# Altium schematic sheet bmsMaster_can.SchDoc
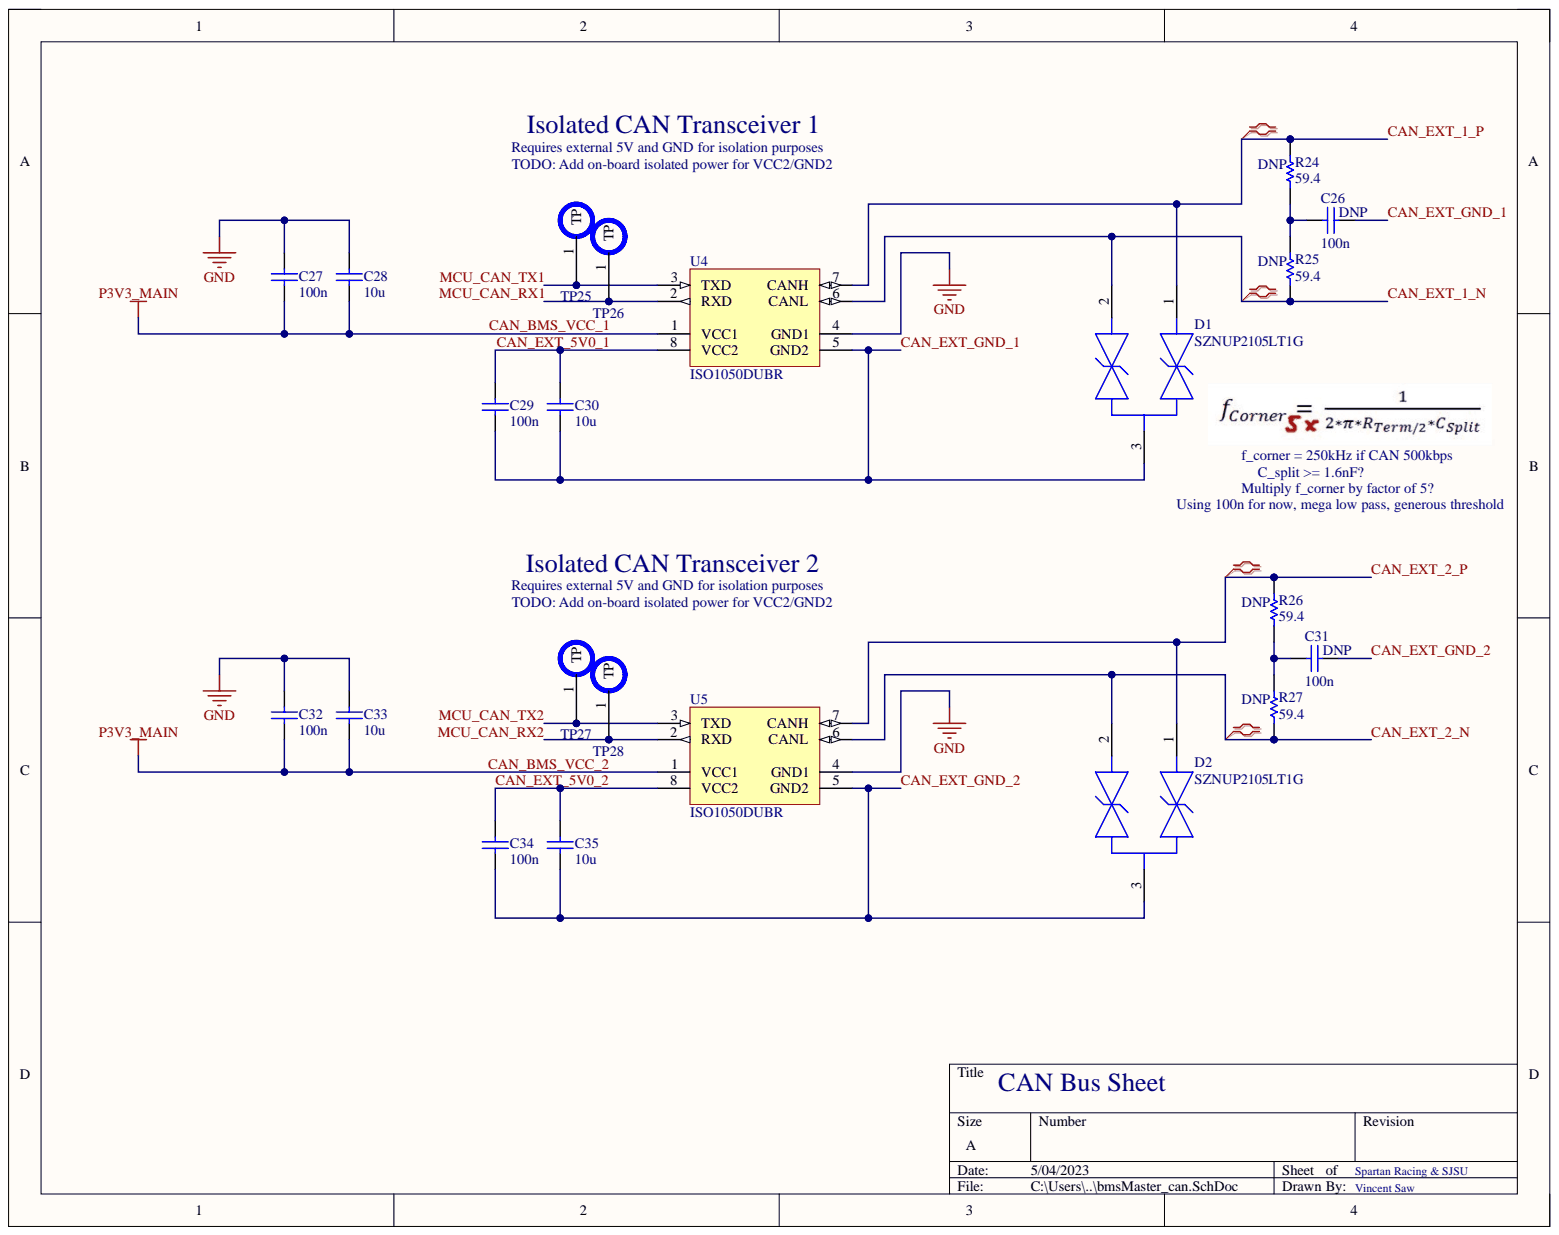
[diagram p0: bmsMaster_can.SchDoc]

## Parts
C26  value 100n  (hidden comment: Capacitor)
C27  value 100n  (hidden comment: Capacitor)
C28  value 10u  (hidden comment: Capacitor)
C29  value 100n  (hidden comment: Capacitor)
C30  value 10u  (hidden comment: Capacitor)
C31  value 100n  (hidden comment: Capacitor)
C32  value 100n  (hidden comment: Capacitor)
C33  value 10u  (hidden comment: Capacitor)
C34  value 100n  (hidden comment: Capacitor)
C35  value 10u  (hidden comment: Capacitor)
D1  SZNUP2105LT1G  [FP-318-08-MFG]
D2  SZNUP2105LT1G  [FP-318-08-MFG]
R24  value 59.4  (hidden comment: Resistor)
R25  value 59.4  (hidden comment: Resistor)
R26  value 59.4  (hidden comment: Resistor)
R27  value 59.4  (hidden comment: Resistor)
TP25  TSTPT  [Testpoint1]  (value not drawn)  (no BOM)
TP26  TSTPT  [Testpoint1]  (value not drawn)  (no BOM)
TP27  TSTPT  [Testpoint1]  (value not drawn)  (no BOM)
TP28  TSTPT  [Testpoint1]  (value not drawn)  (no BOM)
U4  ISO1050DUBR
U5  ISO1050DUBR

## Nets
GND: C27.2 C28.2 C32.2 C33.2 U4.4(GND1) U5.4(GND1)
CAN_BMS_VCC_1: C27.1 C28.1 C32.1 C33.1 U4.1(VCC1) U5.1(VCC1)
CAN_EXT_1_N: D1.2 R25.1 U4.6(CANL)
CAN_EXT_1_P: D1.1 R24.2 U4.7(CANH)
CAN_EXT_2_N: D2.2 R27.1 U5.6(CANL)
CAN_EXT_2_P: D2.1 R26.2 U5.7(CANH)
CAN_EXT_5V0_1: C29.2 C30.2 U4.8(VCC2)
CAN_EXT_5V0_2: C34.2 C35.2 U5.8(VCC2)
CAN_EXT_GND_1: C26.1 C29.1 C30.1 D1.3 U4.5(GND2)
CAN_EXT_GND_2: C31.1 C34.1 C35.1 D2.3 U5.5(GND2)
MCU_CAN_RX1: TP26.1 U4.2(RXD)
MCU_CAN_RX2: TP28.1 U5.2(RXD)
MCU_CAN_TX1: TP25.1 U4.3(TXD)
MCU_CAN_TX2: TP27.1 U5.3(TXD)
NetC26_2: C26.2 R24.1 R25.2
NetC31_2: C31.2 R26.1 R27.2

## Library data of the parts
C26-C35 = Capacitor: SimulationGenericComponent: True; Component Kind: Standard; Description: Capacitor; Library Name: Simulation Generic Components.IntLib; Simulation: C_Capacitor_Default
D1 D2 = CMP-1063-00167-2: TVS DIODE 24V 44V SOT23-3; Manufacturer: ON Semiconductor; Automotive Grade: Grade 0; Breakdown Voltage: 26.2V; Capacitance: 30pF; Direction: Bidirectional; Max Junction Temp: +150°C; Number Of Channels: 2; Peak Pulse Current: 8A; Peak Pulse Power: 350W; Standoff Height: 0.01mm; Value: 30pF; Automotive: Yes; Category: Diode; Clamping Voltage: 44V; Component Kind: Standard; ComponentLink2Description: Datasheet; ComponentLink2URL: https://www.onsemi.com/pub/Collateral/NUP2105L-D.PDF; Device Class L1: Circuit Protection; Device Class L2: TVS Diodes; Device Class L3: unset; Digikey Description: TVS DIODE 24V 44V SOT23-3; Footprint Url: https://www.onsemi.com/pub/Collateral/318-08.PDF; Height: 1.11mm; Lead Free: Yes; Library Name: Altium Content Vault; Manufacturer Part Number: SZNUP2105LT1G; Octopart: https://octopart.com/sznup2105lt1g-on+semiconductor-22134626; Package: 318-08; Reverse Standoff Voltage: 24V; RoHS: TRUE; Temperature: -55°C to +150°C; Temperature Range High: +150°C; Temperature Range Low: -55°C
R24-R27 = Resistor: SimulationGenericComponent: True; TC1: 0; Component Kind: Standard; Description: Resistor; Library Name: Simulation Generic Components.IntLib; Simulation: R_Resistor_Default; TC2: 0
TP25-TP28 = Testpoint1: Library Name: testpoint1.SchLib
U4 U5 = CMP-0315-00093-2: Isolated CAN Transceiver, -55 to 105 degC, 8-pin SOP (DUB), Green (RoHS & no Sb/Br); Manufacturer: Texas Instruments; Drivers Per Package: 1; Isolated: Yes; Rating: Catalog; Component Kind: Standard; ComponentLink1Description: Datasheet; ComponentLink1URL: http://www.ti.com/general/docs/lit/getliterature.tsp?genericPartNumber=ISO1050&fileType=pdf; ComponentLink2Description: Package Specification; ComponentLink2URL: http://www.ti.com/litv/pdf/mpds247b; DatasheetVersion: SLLS983E; Eco-Plan: Green (RoHS & no Sb/Br); Library Name: Altium Content Vault; Mounting Technology: Surface Mount; PackageDescription: 8-Pin Small Outline Package 2.54 mm Pitch; PackageReference: DUB0008A; PackageVersion: revC, May-2006; PartNumber: ISO1050DUBR; SubFamily: CAN
